# Altium schematic sheet AD8367.SchDoc
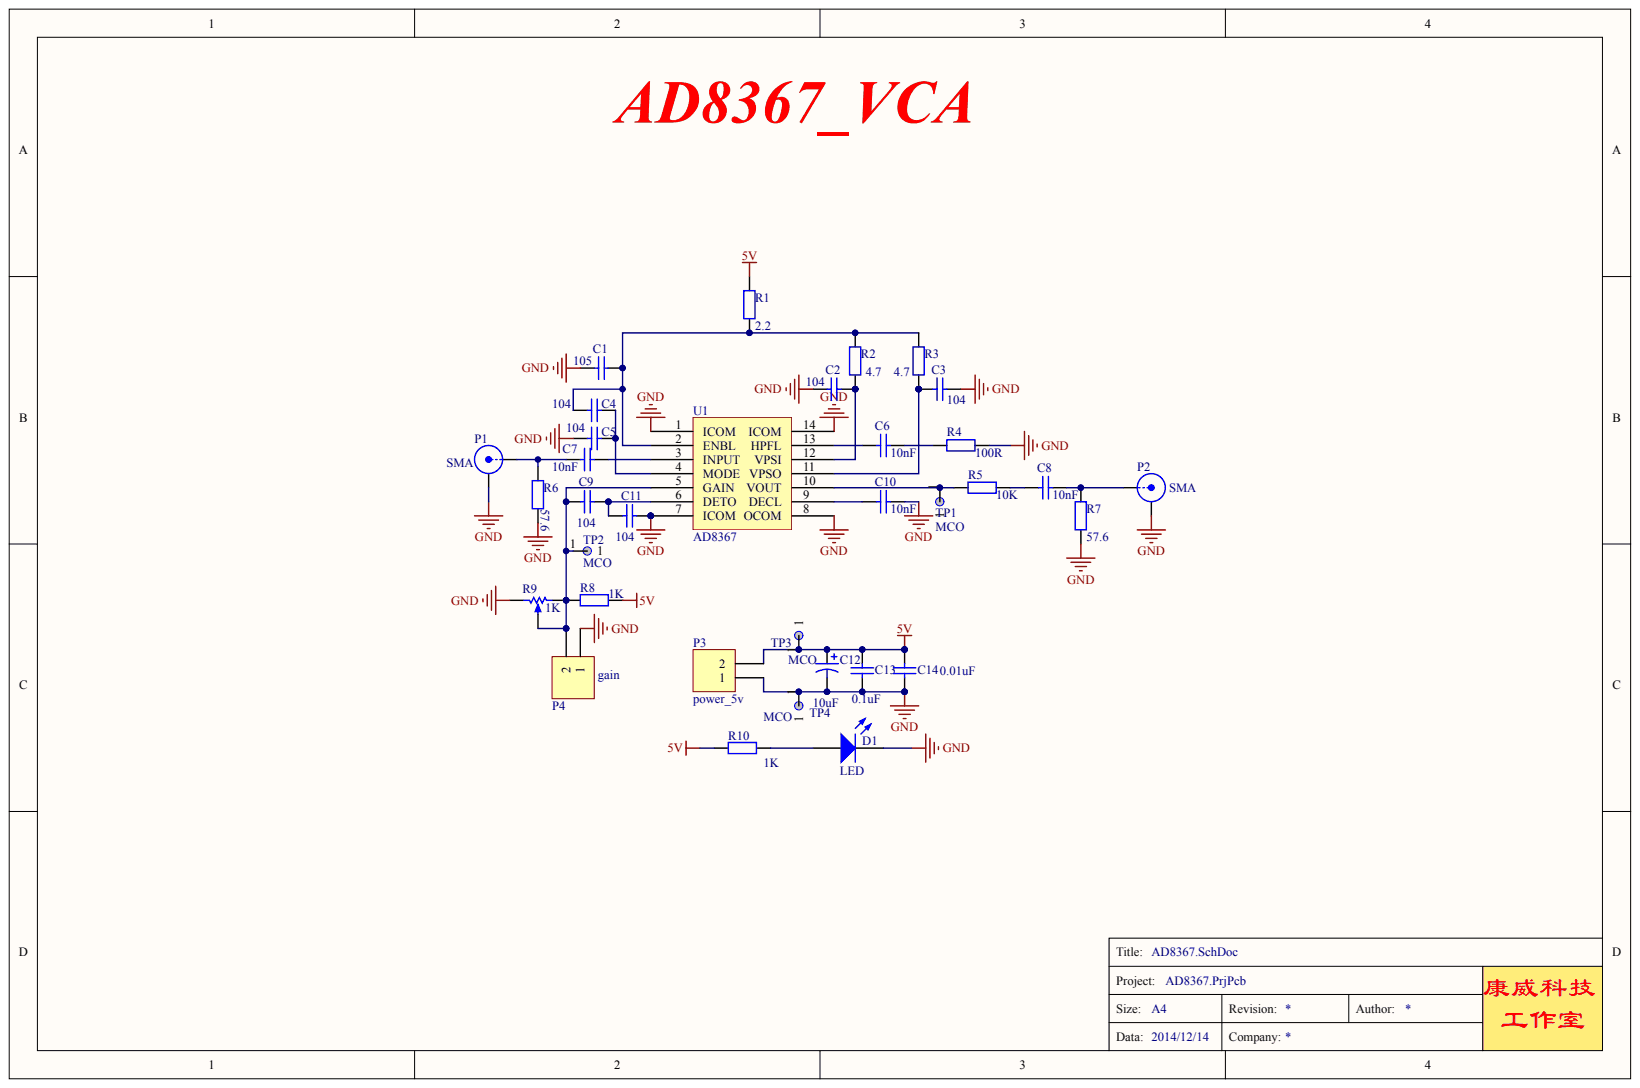
[diagram p0: AD8367.SchDoc]

## Parts
C1  value 105
C2  value 104
C3  value 104
C4  value 104
C5  value 104
C6  value 10nF
C7  value 10nF
C8  value 10nF
C9  value 104
C10  value 10nF
C11  value 104
C12  value 10uF
C13  value 0.1uF
C14  value 0.01uF
D1  LED
P1  SMA
P2  SMA
P3  power_5v
P4  gain
R1  value 2.2
R2  value 4.7
R3  value 4.7
R4  value 100R
R5  value 10K
R6  value 57.6
R7  value 57.6
R8  value 1K
R9  value 1K
R10  value 1K
TP1  MCO
TP2  MCO
TP3  MCO
TP4  MCO
U1  AD8367

## Nets
5V: C12.1 C13.2 C14.2 P3.2 R1.1 R8.2 R10.1 TP3.1
GND: C1.1 C2.1 C3.2 C5.1 C10.1 C11.2 C12.2 C13.1 C14.1 D1.2 P1.2 P2.2 P3.1 P4.1 R4.2 R6.1 R7.1 R9.2 TP4.1 U1.1(ICOM) U1.7(ICOM) U1.8(OCOM) U1.14(ICOM)
NetC1_2: C1.2 C4.1 R1.2 R2.1 R3.1 U1.2(ENBL)
NetC2_2: C2.2 R2.2 U1.12(VPSI)
NetC3_1: C3.1 R3.2 U1.11(VPSO)
NetC4_2: C4.2 C5.2 U1.4(MODE)
NetC6_1: C6.1 R4.1
NetC6_2: C6.2 U1.13(HPFL)
NetC7_1: C7.1 U1.3(INPUT)
NetC7_2: C7.2 P1.1 R6.2
NetC8_1: C8.1 P2.1 R7.2
NetC8_2: C8.2 R5.1
NetC9_1: C9.1 C11.1 U1.6(DETO)
NetC9_2: C9.2 P4.2 R8.1 R9.1 R9.3 TP2.1 U1.5(GAIN)
NetC10_2: C10.2 U1.9(DECL)
NetD1_1: D1.1 R10.2
NetR5_2: R5.2 TP1.1 U1.10(VOUT)
AD8367_VCA:

## Library data of the parts
R9 = (no symbol name): Sim Note: Enter the Resistance value and the set point into parameter fields.; Set Position: 0.5; Published: 8-Jun-2000; LatestRevisionDate: 17-Jul-2002; LatestRevisionNote: Re-released for DXP Platform.; PackageReference: VR5; PackageDescription: Variable Resistor, Thru-Hole; 3 Leads; Publisher: Altium Limited
C1-C14 D1 P1-P4 R1-R8 R10 TP1-TP4 U1 = (no symbol name)
  C1-C11 C13 C14: Published: 8-Jun-2000; LatestRevisionDate: 17-Jul-2002; LatestRevisionNote: Re-released for DXP Platform.; PackageReference: RAD-0.3; PackageDescription: Radial Cap, Thru-Hole; 2 Leads; 0.3 in Pin Spacing
  C12: Published: 8-Jun-2000; LatestRevisionDate: 17-Jul-2002; LatestRevisionNote: Re-released for DXP Platform.; PackageReference: RB7.6-15; PackageDescription: Polarized Capacitor (Radial); 2 Leads
  D1: Published: 8-Jun-2000; LatestRevisionDate: 08-Jul-2005; LatestRevisionNote: Mech Layer 1 removed.; PackageReference: LED-0; PackageDescription: LED; 2 Leads
  P1-P4: LatestRevisionDate: 17-Jul-2002; LatestRevisionNote: Re-released for DXP Platform.
  R1-R8 R10: Published: 8-Jun-2000; LatestRevisionDate: 17-Jul-2002; LatestRevisionNote: Re-released for DXP Platform.; PackageReference: AXIAL-0.4; PackageDescription: Axial Device, Thru-Hole; 2 Leads; 0.4 in Pin Spacing
  C1-C14 D1 P1-P4 R1-R8 R10: Publisher: Altium Limited
